# Altium schematic sheet Sheet1.SchDoc
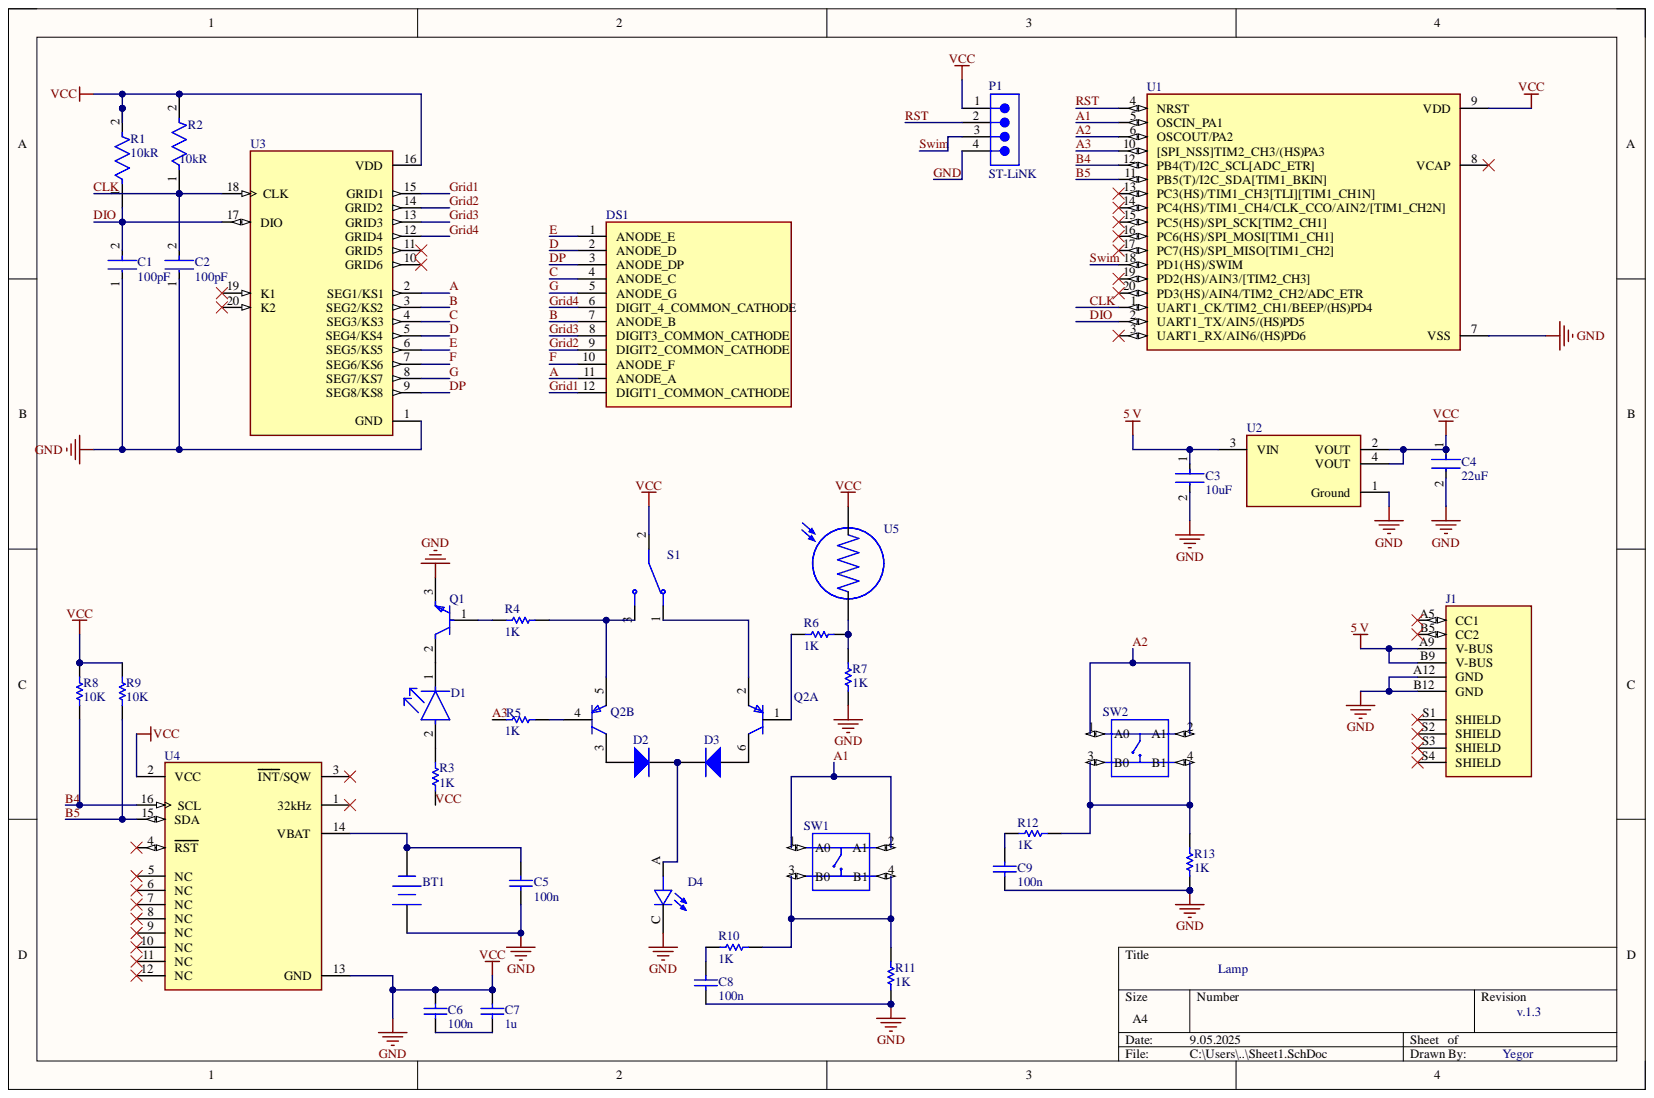
[diagram p0: Sheet1.SchDoc]

## Parts
BT1  (no value)  [PANA-CR-2032HFN]
C1  100pF  [FP-C1608-080-0_1-MFG]
C2  100pF  [FP-C1608-080-0_1-MFG]
C3  10uF  [FP-CL21-IPC_A]
C4  22uF  [FP-CL32-IPC_A]
C5  value 100n  (hidden comment: Capacitor)
C6  value 100n  (hidden comment: Capacitor)
C7  value 1u  (hidden comment: Capacitor)
C8  value 100n  (hidden comment: Capacitor)
C9  value 100n  (hidden comment: Capacitor)
D1  (no value)  [FP-6_541-5092_01-4-MFG]
D2  Diode  (value not drawn)
D3  Diode  (value not drawn)
D4  (no value)  [LEDC1608X65N]
DS1  (no value)  [AVAGO_HDSP-B09G]
J1  (no value)  [CUI_UJC-HP-3-SMT-TR]
P1  ST-LiNK  [61300411021]
Q1  (no value)  [PLZZ0004CA-A_MFG]
Q2  (no value)  [SOT95P280X145-6_P6_064_N]  (parts A,B)
R1  10kR  [FP-CRCW1206-e3-IPC_B]
R2  10kR  [FP-CRCW1206-e3-IPC_B]
R3  value 1K  (hidden comment: Resistor)
R4  value 1K  (hidden comment: Resistor)
R5  value 1K  (hidden comment: Resistor)
R6  value 1K  (hidden comment: Resistor)
R7  value 1K  (hidden comment: Resistor)
R8  value 10K  (hidden comment: Resistor)
R9  value 10K  (hidden comment: Resistor)
R10  value 1K  (hidden comment: Resistor)
R11  value 1K  (hidden comment: Resistor)
R12  value 1K  (hidden comment: Resistor)
R13  value 1K  (hidden comment: Resistor)
S1  (no value)  [SW_MINI-SPDT-SW]
SW1  (no value)  [SW4-SMD-5.2X5.2X1.5MM]
SW2  (no value)  [SW4-SMD-5.2X5.2X1.5MM]
U1  (no value)  [SOP65P640X120-20N]
U2  (no value)  [FP-AMS1117-IPC_A]
U3  (no value)  [DIP778W43P254L2612H431Q20N]
U4  (no value)  [FP-W16-H2-MFG]
U5  (no value)  [XDCR_PDV-P8003]

## Nets
5 V: C3.1 J1.A9(V-BUS) J1.B9(V-BUS) U2.3(VIN)
A: DS1.11(ANODE_A) U3.2(SEG1/KS1)
A1: SW1.1(A0) SW1.2(A1) U1.5(OSCIN_PA1)
A2: SW2.1(A0) SW2.2(A1) U1.6(OSCOUT/PA2)
A3: R5.2 U1.10([SPI_NSS]TIM2_CH3/(HS)PA3)
B: DS1.7(ANODE_B) U3.3(SEG2/KS2)
B4: R8.1 U1.12(PB4(T)/I2C_SCL[ADC_ETR]) U4.16(SCL)
B5: R9.1 U1.11(PB5(T)/I2C_SDA[TIM1_BKIN]) U4.15(SDA)
C: DS1.4(ANODE_C) U3.4(SEG3/KS3)
CLK: C2.2 R2.1 U1.1(UART1_CK/TIM2_CH1/BEEP/(HS)PD4) U3.18(CLK)
D: DS1.2(ANODE_D) U3.5(SEG4/KS4)
DIO: C1.2 R1.1 U1.2(UART1_TX/AIN5/(HS)PD5) U3.17(DIO)
DP: DS1.3(ANODE_DP) U3.9(SEG8/KS8)
E: DS1.1(ANODE_E) U3.6(SEG5/KS5)
F: DS1.10(ANODE_F) U3.7(SEG6/KS6)
G: DS1.5(ANODE_G) U3.8(SEG7/KS7)
GND: BT1.1 C1.1 C2.1 C3.2 C4.1 C4.2 C5.1 C6.2 C7.2 C8.1 C9.1 D4.C J1.A12(GND) J1.B12(GND) P1.1 P1.4 Q1.3 R1.2 R2.2 R3.1 R7.1 R8.2 R9.2 R11.1 R13.1 S1.2 U1.7(VSS) U1.9(VDD) U2.1(Ground) U2.2(VOUT) U2.4(VOUT) U3.1(GND) U3.16(VDD) U4.2(VCC) U4.13(GND) U5.2
Grid1: DS1.12(DIGIT1_COMMON_CATHODE) U3.15(GRID1)
Grid2: DS1.9(DIGIT2_COMMON_CATHODE) U3.14(GRID2)
Grid3: DS1.8(DIGIT3_COMMON_CATHODE) U3.13(GRID3)
Grid4: DS1.6 U3.12(GRID4)
RST: P1.2 U1.4(NRST)
Swim: P1.3 U1.18(PD1(HS)/SWIM)
NetBT1_2: BT1.2 C5.2 U4.14(VBAT)
NetC6_1: C6.1 C7.1
NetC8_2: C8.2 R10.1
NetC9_2: C9.2 R12.1
NetD1_1: D1.1 Q1.2
NetD1_2: D1.2 R3.2
NetD2_1: D2.1 Q2.3
NetD2_2: D2.2 D3.2 D4.A
NetD3_1: D3.1 Q2.6
NetQ1_1: Q1.1 R4.1
NetQ2_1: Q2.1 R6.1
NetQ2_2: Q2.2 S1.1
NetQ2_4: Q2.4 R5.1
NetQ2_5: Q2.5 R4.2 S1.3
NetR6_2: R6.2 R7.2 U5.1
NetR10_2: R10.2 R11.2 SW1.3(B0) SW1.4(B1)
NetR12_2: R12.2 R13.2 SW2.3(B0) SW2.4(B1)

Not connected: J1 A5(CC1) B5(CC2) S1(SHIELD) S2(SHIELD) S3(SHIELD) S4(SHIELD); U1 3(UART1_RX/AIN6/(HS)PD6) 8(VCAP) 13(PC3(HS)/TIM1_CH3[TLI][TIM1_CH1N]) 14(PC4(HS)/TIM1_CH4/CLK_CCO/AIN2/[TIM1_CH2N]) 15(PC5(HS)/SPI_SCK[TIM2_CH1]) 16(PC6(HS)/SPI_MOSI[TIM1_CH1]) 17(PC7(HS)/SPI_MISO[TIM1_CH2]) 19(PD2(HS)/AIN3/[TIM2_CH3]) 20(PD3(HS)/AIN4/TIM2_CH2/ADC_ETR); U3 10(GRID6) 11(GRID5) 19(K1) 20(K2); U4 1(32kHz) 3(INT/SQW) 4(RST) 5(NC) 6(NC) 7(NC) 8(NC) 9(NC) 10(NC) 11(NC) 12(NC)

## Library data of the parts
BT1 = CMP-2000-05296-1: Coin Cell Battery, 225 mAh, 0.2 mA, 3 V, -30 to 60 degC, Bulk; Manufacturer: Panasonic; Battery Capacity: 220mAh; Battery IEC Code: CR2032; Battery NEDA Code: 5004LC; Battery Size Code: 2032; Battery Technology: LithiumManganeseDioxide; Battery Terminals: PCBPins; Battery Voltage: 3V; External Diameter: 20mm; External Height: 3.2mm; SVHC: 1,2-dimethoxyethane,ethyleneglycoldimethylether(EGDME)(15-Jun-2015); Component Kind: Standard; Datasheet URL: https://datasheet.ciiva.com/3/aaa4000de87-3072.pdf; Datasheet Version: Rev. 0, 05/2010; External Depth: -; External Width: -; Library Name: Components - 25.08.25 - 13.52.IntLib; Manufacturer URL: http://www.panasonic.com/; Product Range: -; Weight: 2.9g
C1 C2 = CMP-08246-002637-1: Multilayer Ceramic Capacitors 100pF ±5% 50V C0G SMD 0603; Temperature Coefficient: 30ppm/°C; Capacitance: 100pF; Case Code (Imperial): 0603; Case Code (Metric): 1608; Case/Package: 0603; Component Kind: Standard; Construction: Flat; Depth: 0.8mm; Dielectric: C0G; Dielectric Material: Ceramic; Height: 0.8mm; Lead Free: Lead Free; Length: 1.6mm; Library Name: Components - 25.08.25 - 11.32.IntLib; Max Operating Temperature: 125°C; Min Operating Temperature: -55°C; Mount: Surface Mount; Number of Pins: 2; Package Quantity: 1; Packaging: Tape and Reel; Radiation Hardening: No; REACH SVHC: No SVHC; RoHS Compliant: Yes; Series: C; Termination: SMD/SMT; Thickness: 0.037inch; Tolerance: 5%; Voltage: 50V; Voltage Rating: 50V; Voltage Rating (DC): 50V; Weight: 7.1E-05oz; Width: 0.031inch
C3 = CMP-13271-002245-1: CL21 Series 0805 10uF 10V ±20% Tolerance X5R Multilayer Ceramic Chip Capacitor; Capacitance: 10uF; Case/Package: 0805; Component Kind: Standard; Depth: 1.25mm; Dielectric: X5R; Height: 1.25mm; Length: 2mm; Library Name: Components - 25.08.25 - 12.18.IntLib; Max Operating Temperature: 85°C; Min Operating Temperature: -55°C; Mount: Surface Mount; Package Quantity: 10000; Packaging: Tape and Reel; Radiation Hardening: No; Thickness: 0.053inch; Tolerance: 20%; Voltage Rating: 10V; Voltage Rating (DC): 10V; Width: 0.049inch
C4 = CMP-13271-001877-1: Cap Ceramic 22uF 6.3V X7R ±20% SMD 1210 +125°C Embossed T/R; Capacitance: 22uF; Case Code (Imperial): 1210; Case Code (Metric): 3225; Case/Package: 1210; Component Kind: Standard; Depth: 2.5mm; Dielectric: X7R; Height: 2.5mm; Lead Free: Lead Free; Length: 3.2mm; Library Name: Components - 25.08.25 - 12.17.IntLib; Max Operating Temperature: 125°C; Min Operating Temperature: -55°C; Mount: Surface Mount; Package Quantity: 1; Packaging: Tape and Reel; Radiation Hardening: No; Thickness: 0.106inch; Tolerance: 20%; Voltage Rating: 6.3V; Voltage Rating (DC): 6.3V; Width: 0.098inch
C5-C9 = Capacitor: SimulationGenericComponent: True; Component Kind: Standard; Description: Capacitor; Library Name: Simulation Generic Components.IntLib; Simulation: C_Capacitor_Default
D1 = CMP-02520-000012-1: LED RED 0603 SMD; Manufacturer: Vishay; Manufacturer Part Number: VLMS1300-GS08; Forward Current: 20mA; Forward Voltage: 2V; Led Orientation: Top View; Luminous Intensity: 54mcd; Peak Emmision Wavelength: 639nm; Power Dissipation: 75mW; Reverse Voltage: 5V; Viewing Angle: 130°; Wavelength: 631nm; Ambient Temperature Range High: +85°C; Ambient Temperature Range Low: -35°C; Automotive: No; Category: Diode; Colour: Red; Component Kind: Standard; ComponentLink2Description: Datasheet; ComponentLink2URL: http://www.vishay.com/docs/82437/vlmo1300.pdf; Device Class L1: Optoelectronics; Device Class L2: LEDs; Device Class L3: unset; Digikey Description: LED RED 0603 SMD; Height: 1mm; Lead Free: Yes; Library Name: Components - 25.08.25 - 21.29.IntLib; Mouser Description: Standard LEDs - SMD Super Red Clear Non-Diff; Octopart: https://octopart.com/vlms1300-gs08-vishay-21709201; Package: 6.541-5092.01-4; RoHS: TRUE; Temperature: -35°C to +85°C; Temperature Range High: +85°C; Temperature Range Low: -35°C
D2 D3 = Diode: AF: 1; BV: 0; BV_MAX: 1e99; EG: 1.11; FC: 0.5; IBV: 0.001; IS: 1e-14; MJSW: 0.33; NTUN: 30; SimulationGenericComponent: True; TNOM: 27; XTI: 3; CJO: 0; CJP: 0; Component Kind: Standard; CTA: 0; CTP: 0; Description: Diode; FCS: 0.5; FV_MAX: 1e99; IKF: 0; IKR: 0; ISR: 1e-14; JSW: 0; JTUN: 0; JTUNSW: 0; KEG: 1; KF: 0; LEVEL: 1; Library Name: Simulation Generic Components.IntLib; N: 1; NBV: 1; NR: 1; NS: 1; PHP: 1; RS: 0; Simulation: diode; TCV: 0; TLEV: 0; TLEVC: 0; TM1: 0; TM2: 0; TPB: 0; TPHP: 0; TRS: 0; TRS2: 0; TT: 0; TTT1: 0; TTT2: 0; VJ: 1; XTITUN: 3
D4 = LTW-C191TLA: CHIP LED WHITE 0603 TOP VIEW; Availability: Unavailable; Component Kind: Standard; Library Name: LTW-C191TLA.IntLib; MP: LTW-C191TLA; Package: None; Price: None
DS1 = HDSP-B09G: Display Modules - LED Character and Numeric Green 7-Segment Clock 4 Character Common Cathode 2.2V 20mA 0.752 H x 2.016 W x 0.315 D (19.10mm x 51.20mm x 8.00mm) 12-DIP (0.600, 15.24mm); MF: Broadcom / Avago; Check_prices: https://www.snapeda.com/parts/HDSP-B09G/Broadcom/view-part/?ref=eda; Component Kind: Standard; Library Name: HDSP-B09G.IntLib; MP: HDSP-B09G; Package: None; SnapEDA_Link: https://www.snapeda.com/parts/HDSP-B09G/Broadcom/view-part/?ref=snap
J1 = UJC-HP-3-SMT-TR: Availability: Unavailable; Component Kind: Standard; Library Name: UJC-HP-3-SMT-TR.IntLib; MP: UJC-HP-3-SMT-TR; Package: None; Price: None
P1 = CMP-1502-01063-3: NexarPartId: 31153320; Connector Type: Male Pin; Contact Resistance: 20mΩ; Current Rating: 3A; Gender: Male; Number of Contacts: 4; Operating Supply Voltage: 250V; Pitch: 2.54mm; Color: Black; Component Kind: Standard; Contact Material: Copper; Contact Mating Length: 5.9944mm; Contact Plating: Gold; Lead Pitch: 2.54mm; Library Name: Components - 25.08.25 - 11.48.IntLib; Mount: Through Hole; Number of Pins: 4; Number of Positions: 4; Number of Rows: 1; REACH SVHC: Unknown; RoHS: Compliant; Termination: Solder
Q1 = CMP-00572-18697870-1: Trans GP BJT NPN 50V 1A 4-Pin(3+Tab) UPAK T/R; Manufacturer Lifecycle Status: OBSOLETE; Collector Base Voltage (VCBO): 100V; Emitter Base Voltage (VEBO): 6V; Frequency: 100MHz; Number of Elements: 1; Power Dissipation: 1W; China RoHS: Compliant; Collector Emitter Voltage (VCEO): 50V; Component Kind: Standard; ComponentLink1Description: Manufacturer's Website; ComponentLink1URL: http://www.renesas.com; ComponentLink2Description: Datasheet URL; ComponentLink2URL: https://octopart.com/cad-content/api/get-model-datasheet/15189/18697870; ComponentLink3Description: Manufacturer's Package Drawing; ComponentLink3URL: https://www.renesas.com/en/document/psc/package-drawing-upak-4pin-plzz0004ca; Height: 1.6mm; HelpURL: https://octopart.com/cad-content/api/get-model-datasheet/15189/18697870; Lead Free: Lead Free; Library Name: Components - 25.08.25 - 21.28.IntLib; Lifecycle Status: Obsolete; Max Operating Temperature: 150°C; Min Operating Temperature: -55°C; Mount: Through Hole; Number of Pins: 4; Packaging: Tape and Reel; Polarity: NPN; Radiation Hardening: No; RoHS: Compliant
Q2 = CMP-00572-88253858-3: Transistor, Bjt, Pair, Pnp, 11V V(Br)Ceo, 14Ma I(C), So; Manufacturer Lifecycle Status: ACTIVE; Collector Base Voltage (VCBO): 12V; Collector Emitter Breakdown Voltage: 9V; Continuous Collector Current: 26mA; Element Configuration: Dual; Emitter Base Voltage (VEBO): 4.5V; Frequency: 7GHz; Noise Figure: 2.6dB; Pitch: 1mm; Case/Package: SOT-23-6; Collector Emitter Voltage (VCEO): 11V; Component Kind: Standard; ComponentLink1Description: Manufacturer's Website; ComponentLink1URL: http://www.renesas.com; ComponentLink2Description: Datasheet URL; ComponentLink2URL: https://octopart.com/cad-content/api/get-model-datasheet/15189/88253858; ComponentLink3Description: Manufacturer's Package Drawing; ComponentLink3URL: https://www.renesas.com/en/document/psc/package-drawing-sot23-6pin-p6064; Current Rating: 14mA; Export Control Classification Number (ECCN) Code: EAR99; Gain Bandwidth Product: 7GHz; Height: 0m; HelpURL: https://octopart.com/cad-content/api/get-model-datasheet/15189/88253858; Lead Free: Lead Free; Length: 2.9mm; Library Name: Components - 25.08.25 - 12.53.IntLib; Lifecycle Status: Production; Max Collector Current: 26mA; Max Frequency: 7GHz; Max Operating Temperature: 85°C; Min Operating Temperature: -40°C; Mount: Surface Mount; Number of Pins: 6; Polarity: PNP; Radiation Hardening: No; RoHS: Compliant; Schedule B: 8542330000; Transition Frequency: 7GHz; Voltage Rating (DC): 11V; Width: 1.7mm
R1 R2 = CMP-2003-04807-2: RES Thick Film, 10kΩ, 1%, 0.25W, 100ppm/°C, 1206; Max Power Dissipation: 250mW; Voltage Rating: 200V; Case Code (Imperial): 1206; Case Code (Metric): 3216; Case/Package: 1206; Component Kind: Standard; Composition: Thick Film; Contact Plating: Tin; Depth: 1.6mm; Features: Automotive AEC-Q200; Height: 0.55mm; Lead Free: Lead Free; Length: 3.2mm; Library Name: Components - 25.08.25 - 11.27.IntLib; Max Operating Temperature: 155°C; Military Standard: Not; Min Operating Temperature: -55°C; Number of Pins: 2; Number of Terminations: 2; Package Quantity: 10; Packaging: Tape and Reel; Power Rating: 250mW; Radiation Hardening: No; Resistance: 10kR; Temperature Coefficient: 100ppm/°C; Termination: SMD/SMT; Tolerance: 1%; Voltage Rating (DC): 200V; Weight: 0.000353oz; Width: 0.063inch; Working Voltage: 200V
R3-R13 = Resistor: SimulationGenericComponent: True; TC1: 0; Component Kind: Standard; Description: Resistor; Library Name: Simulation Generic Components.IntLib; Simulation: R_Resistor_Default; TC2: 0
S1 = MINI-SPDT-SW: Simple breadboard friendly SPDT slide switch. Rated at 30V/200mA with operating force of 250 +/-100gf.; Availability: Unavailable; Component Kind: Standard; Library Name: MINI-SPDT-SW.IntLib; MP: MINI-SPDT-SW; Package: None; Price: None
SW1 SW2 = SMD-BUTTON(4P-5.2X5.2X1.5MM-SKQGAKE010): Switch Tactile N.O. SPST Button Gull Wing 0.05A 12VDC 3.43N SMD T/R; MF: ALPS; Availability: Unavailable; Component Kind: Standard; Library Name: SMD-BUTTON_4P-5.2X5.2X1.5MM-SKQGAKE010_.IntLib; MP: SKQGAKE010; Package: None; Price: None; SnapEDA_Link: https://www.snapeda.com/parts/SKQGAKE010/ALPS/view-part/51324/?ref=snap
U1 = STM8S103F3P6: STM8 series Microcontroller IC 8-Bit 16MHz 8KB (8K x 8) FLASH 20-TSSOP; MF: STMicroelectronics; Check_prices: https://www.snapeda.com/parts/STM8S103F3P6/STMicroelectronics/view-part/?ref=eda; Component Kind: Standard; Library Name: STM8S103F3P6.IntLib; MP: STM8S103F3P6; Package: TSSOP-20 STMicroelectronics; SnapEDA_Link: https://www.snapeda.com/parts/STM8S103F3P6/STMicroelectronics/view-part/?ref=snap
U2 = CMP-209535-000001-1: LDO Voltage Regulators 1A, 3.3V; Manufacturer: Advanced Monolithic Systems; Manufacturer Part Number: AMS1117-3.3; Dropout Voltage: 1.1V; Max Junction Temp: +125°C; Max Power Dissipation: 1.2W; Max Supply Voltage: 15V; Nominal Supply Current: 5mA; Number Of Outputs: 1; Output Type: Fixed; Standoff Height: 0.64mm; Automotive: No; Category: IC; Component Kind: Standard; ComponentLink2Description: Datasheet; ComponentLink2URL: http://www.advanced-monolithic.com/pdf/ds1117.pdf; Device Class L1: Integrated Circuits (ICs); Device Class L2: Power Management ICs; Device Class L3: Voltage Regulators - Linear; Height: 1.8mm; Ipc Land Pattern Name: SOT229P700X180-4; Lead Free: Yes; Library Name: Components - 25.08.25 - 12.03.IntLib; Octopart: https://octopart.com/ams1117-3.3-advanced+monolithic+systems-25899300; Output Current: 1A; Output Voltage: 3.3V; Package: AMS1117; RoHS: TRUE; Temperature: -40°C to +125°C; Temperature Range High: +125°C; Temperature Range Low: -40°C
U3 = TM1637: LED Drive Control Special Circuit; MF: Titan Micro Electronics; Check_prices: https://www.snapeda.com/parts/TM1637/Titan+Micro+Electronics/view-part/?ref=eda; Component Kind: Standard; Library Name: TM1637.IntLib; MP: TM1637; Package: None; SnapEDA_Link: https://www.snapeda.com/parts/TM1637/Titan+Micro+Electronics/view-part/?ref=snap
U4 = CMP-0254-00375-2: IC RTC CLK/CALENDAR I2C 16-SOIC; Date Format: YY-MM-DD-dd; Logic Function: Clock; Max Supply Voltage: 5.5V; Min Supply Voltage: 2.3V; Operating Supply Voltage: 3.3V; Time Format: HH:MM:SS; Case/Package: SOIC; Component Kind: Standard; Contact Plating: Tin; Interface: I2C; Lead Free: Lead Free; Library Name: Components - 25.08.25 - 13.49.IntLib; Max Operating Temperature: 85°C; Min Operating Temperature: -40°C; Mount: Surface Mount; Number of Pins: 16; Package Quantity: 1000; Packaging: Tape and Reel; Radiation Hardening: No
U5 = PDV-P8003: CdS Cells 520nm 16 ~ 33kOhms @ 10 lux; MF: Advanced Photonix; Check_prices: https://www.snapeda.com/parts/PDV-P8003/Advanced+Photonix/view-part/?ref=eda; Component Kind: Standard; Library Name: PDV-P8003.IntLib; MP: PDV-P8003; Package: Radial Advanced Photonix; SnapEDA_Link: https://www.snapeda.com/parts/PDV-P8003/Advanced+Photonix/view-part/?ref=snap
